# Altium schematic sheet [02] - HAB.SchDoc("[02] - HAB")
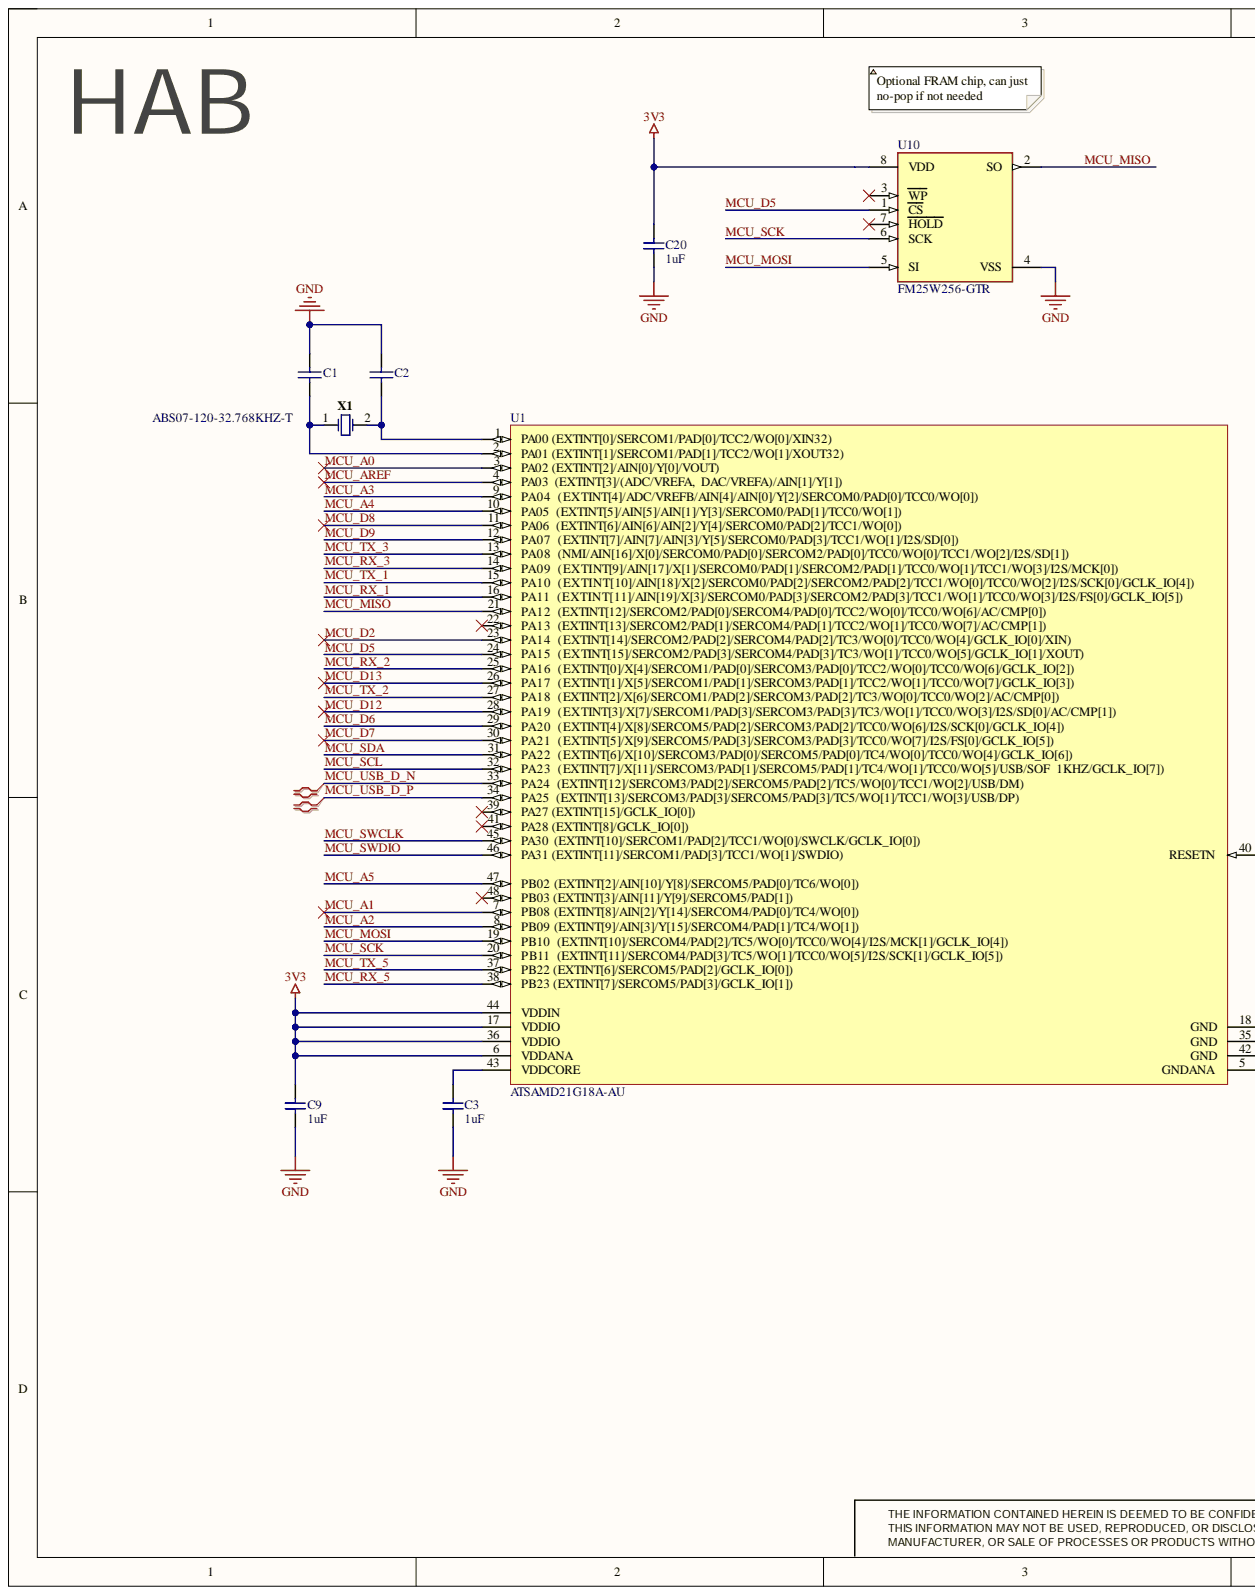
[diagram p0: [02] - HAB.SchDoc, left]
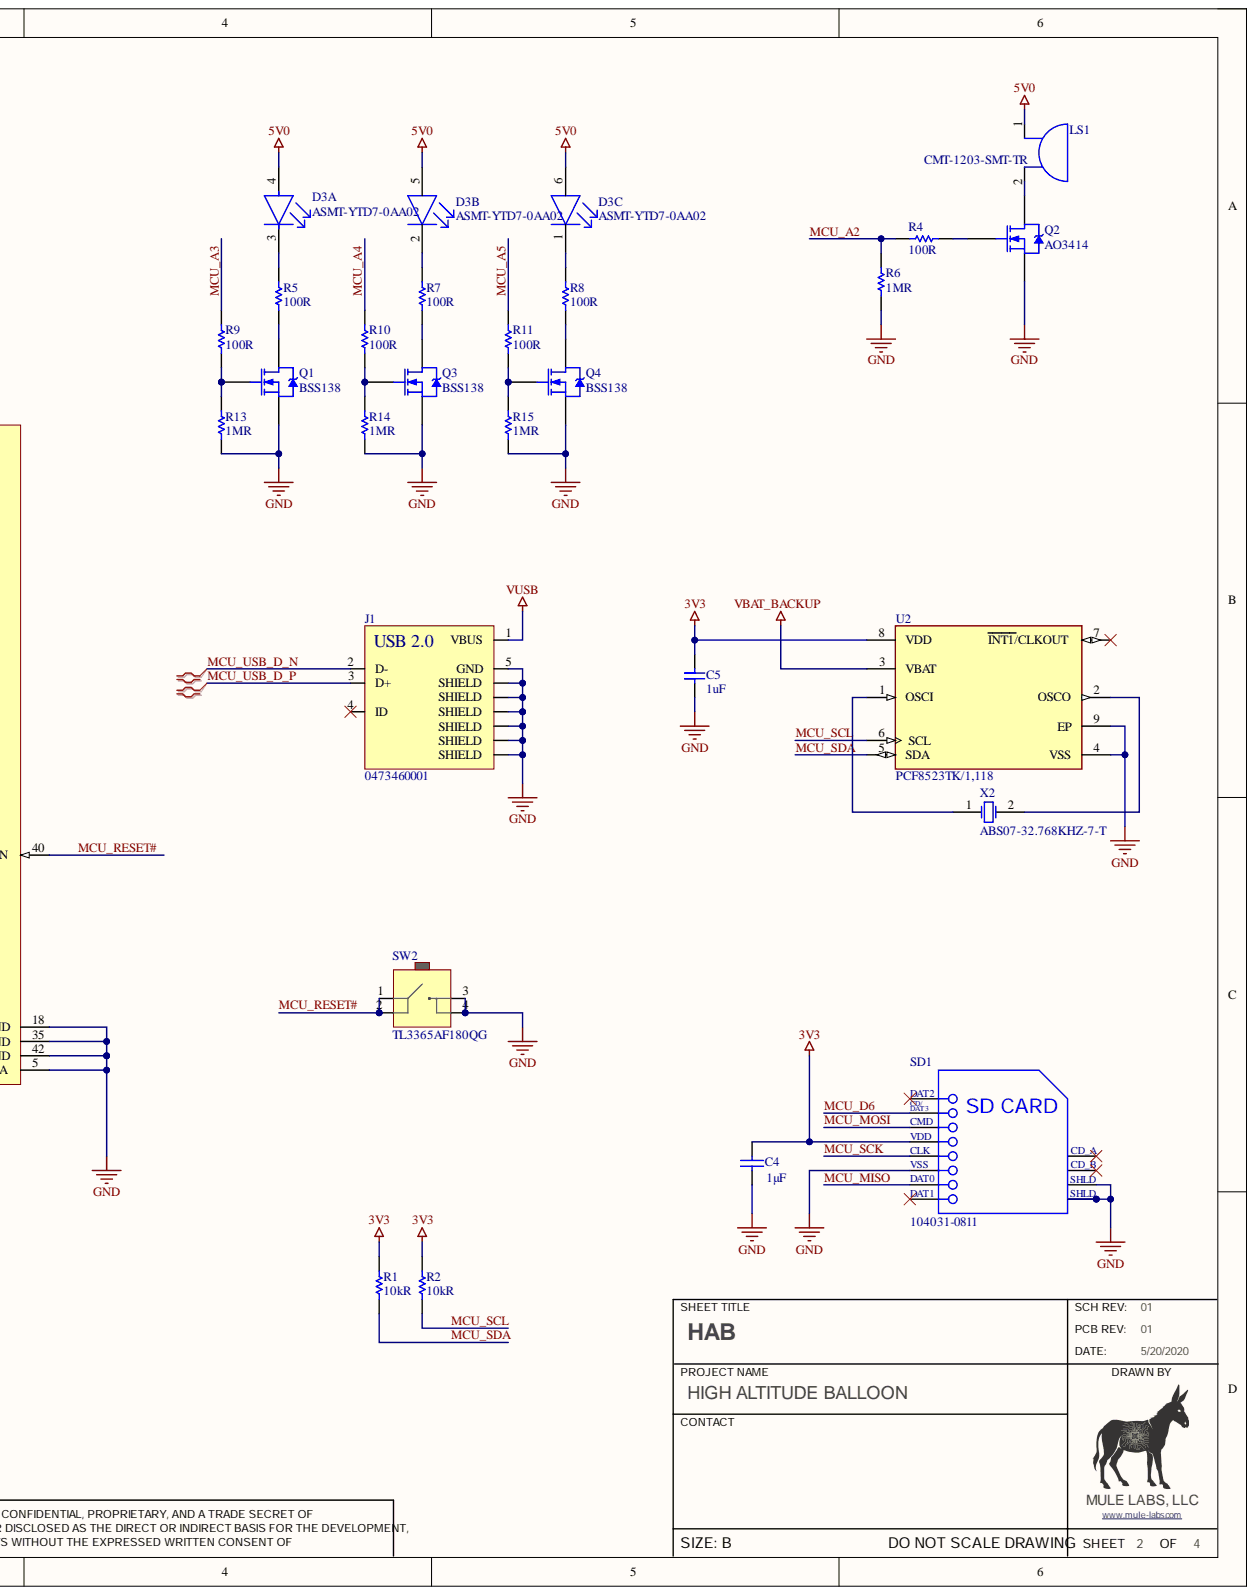
[diagram p1: [02] - HAB.SchDoc, right]

## Parts
C1  GRM21A5C2E220JW01D  [CAPC2013X100X30ML20]  (value not drawn)
C2  GRM21A5C2E220JW01D  [CAPC2013X100X30ML20]  (value not drawn)
C3  1uF  [INCH 0805 - METRIC 2012 - H140]
C4  Capacitance: 1µF  [CAPC2013X135X45ML10T25]  (hidden comment: GRM21BR71C105KA01L)
C5  1uF  [INCH 0805 - METRIC 2012 - H140]
C9  1uF  [INCH 0805 - METRIC 2012 - H140]
C20  1uF  [INCH 0805 - METRIC 2012 - H140]
D3  ASMT-YTD7-0AA02  [FP-PLCC-6-MFG]  (parts A,B,C)
J1  0473460001  Connector Type: USB  [MOLEX_047346-0001]
LS1  CMT-1203-SMT-TR  [CMT-1203-SMT-TR]
Q1  BSS138  [SOT95P240X120-3]
Q2  AO3414  [SOT95P240X120-3]
Q3  BSS138  [SOT95P240X120-3]
Q4  BSS138  [SOT95P240X120-3]
R1  10kR  [INCH 0805 - METRIC 2012 - H070]
R2  10kR  [INCH 0805 - METRIC 2012 - H070]
R4  100R  [INCH 0805 - METRIC 2012 - H070]
R5  100R  [INCH 0805 - METRIC 2012 - H070]
R6  1MR  [INCH 0805 - METRIC 2012 - H070]
R7  100R  [INCH 0805 - METRIC 2012 - H070]
R8  100R  [INCH 0805 - METRIC 2012 - H070]
R9  100R  [INCH 0805 - METRIC 2012 - H070]
R10  100R  [INCH 0805 - METRIC 2012 - H070]
R11  100R  [INCH 0805 - METRIC 2012 - H070]
R13  1MR  [INCH 0805 - METRIC 2012 - H070]
R14  1MR  [INCH 0805 - METRIC 2012 - H070]
R15  1MR  [INCH 0805 - METRIC 2012 - H070]
SD1  104031-0811  [MOLX-104031-0811_V]
SW2  TL3365AF180QG  [ESWITCH_TL3365AF180QG]
U1  ATSAMD21G18A-AU  [ATML-TQFP48_M]
U2  PCF8523TK/1,118  [FP-SOT909-1-MFG]
U10  FM25W256-GTR  [FP-51-85066-MFG]
X1  ABS07-120-32.768KHZ-T  [ABRA-ABS07_A_V]
X2  ABS07-32.768KHZ-7-T  [ABS07]

## Nets
3V3: C4.1 C5.2 C9.2 C20.2 R1.2 R2.2 SD1.4 U1.6(VDDANA) U1.17(VDDIO) U1.36(VDDIO) U1.44(VDDIN) U2.8(VDD) U10.8(VDD)
5V0: D3.4 D3.5 D3.6 LS1.1
GND: C1.2 C2.2 C3.1 C4.2 C5.1 C9.1 C20.1 J1.0(SHIELD) J1.5(GND) Q1.2 Q2.2 Q3.2 Q4.2 R6.1 R13.1 R14.1 R15.1 SD1.6 SD1.11 SD1.12 SW2.3 SW2.4 U1.5(GNDANA) U1.18(GND) U1.35(GND) U1.42(GND) U2.4(VSS) U2.9(EP) U10.4(VSS)
VBAT_BACKUP: U2.3(VBAT)
VUSB: J1.1(VBUS)
MCU_A0: U1.3(PA02_(EXTINT[2]/AIN[0]/Y[0]/VOUT))
MCU_A1: U1.7(PB08_(EXTINT[8]/AIN[2]/Y[14]/SERCOM4/PAD[0]/TC4/WO[0]))
MCU_A2: R4.1 R6.2 U1.8(PB09_(EXTINT[9]/AIN[3]/Y[15]/SERCOM4/PAD[1]/TC4/WO[1]))
MCU_A3: R9.2 U1.9(PA04)
MCU_A4: R10.2 U1.10(PA05)
MCU_A5: R11.2 U1.47(PB02_(EXTINT[2]/AIN[10]/Y[8]/SERCOM5/PAD[0]/TC6/WO[0]))
MCU_AREF: U1.4(PA03)
MCU_D2: U1.23(PA14)
MCU_D5: U1.24(PA15) U10.1(CS)
MCU_D6: SD1.2 U1.29(PA20)
MCU_D7: U1.30(PA21)
MCU_D8: U1.11(PA06)
MCU_D9: U1.12(PA07)
MCU_D12: U1.28(PA19)
MCU_D13: U1.26(PA17)
MCU_MISO: SD1.7 U1.21(PA12) U10.2(SO)
MCU_MOSI: SD1.3 U1.19(PB10) U10.5(SI)
MCU_RESET#: SW2.1 SW2.2 U1.40(RESETN)
MCU_RX_1: U1.16(PA11)
MCU_RX_2: U1.25(PA16)
MCU_RX_3: U1.14(PA09)
MCU_RX_5: U1.38(PB23_(EXTINT[7]/SERCOM5/PAD[3]/GCLK_IO[1]))
MCU_SCK: SD1.5 U1.20(PB11) U10.6(SCK)
MCU_SCL: R2.1 U1.32(PA23) U2.6(SCL)
MCU_SDA: R1.1 U1.31(PA22) U2.5(SDA)
MCU_SWCLK: U1.45(PA30)
MCU_SWDIO: U1.46(PA31_(EXTINT[11]/SERCOM1/PAD[3]/TCC1/WO[1]/SWDIO))
MCU_TX_1: U1.15(PA10)
MCU_TX_2: U1.27(PA18)
MCU_TX_3: U1.13(PA08)
MCU_TX_5: U1.37(PB22_(EXTINT[6]/SERCOM5/PAD[2]/GCLK_IO[0]))
MCU_USB_D_N: J1.2(D-) U1.33(PA24)
MCU_USB_D_P: J1.3(D+) U1.34(PA25)
NetC1_1: C1.1 U1.2(PA01_(EXTINT[1]/SERCOM1/PAD[1]/TCC2/WO[1]/XOUT32)) X1.1
NetC2_1: C2.1 U1.1(PA00_(EXTINT[0]/SERCOM1/PAD[0]/TCC2/WO[0]/XIN32)) X1.2
NetC3_2: C3.2 U1.43(VDDCORE)
NetD3_1: D3.1 R8.2
NetD3_2: D3.2 R7.2
NetD3_3: D3.3 R5.2
NetLS1_2: LS1.2 Q2.3
NetQ1_1: Q1.1 R9.1 R13.2
NetQ1_3: Q1.3 R5.1
NetQ2_1: Q2.1 R4.2
NetQ3_1: Q3.1 R10.1 R14.2
NetQ3_3: Q3.3 R7.1
NetQ4_1: Q4.1 R11.1 R15.2
NetQ4_3: Q4.3 R8.1
NetU2_1: U2.1(OSCI) X2.1
NetU2_2: U2.2(OSCO) X2.2

Not connected: J1 4(ID); SD1 1(SD_CARD) 8 9 10; U1 22(PA13) 39(PA27_(EXTINT[15]/GCLK_IO[0])) 41(PA28_(EXTINT[8]/GCLK_IO[0])) 48(PB03_(EXTINT[3]/AIN[11]/Y[9]/SERCOM5/PAD[1])); U2 7(INT1/CLKOUT); U10 3(WP) 7(HOLD)

## Library data of the parts
C1 C2 = CMP-2007-03977-1: Manufacturer: Murata; Component Kind: Standard; Library Name: Altium Content Vault; Part Number: GRM21A5C2E220JW01D
C3 C5 C9 C20 = GCM21BR71E105KA56L: CAP SMD 1uF 25V 10% 0805; Manufacturer: Murata; Dielectric: X7R; Capacitance: 1uF; Case Code (Imperial): 0805; Case Code (Metric): 2012; Case/Package: 0805; Category: Ceramic Capacitors; Component Kind: Standard; ComponentLink1Description: https://datasheet.ciiva.com/3778/359gcm21br71e105ka5623-3778560.pdf?src-supplier=Arrow; ComponentLink1URL: https://datasheet.ciiva.com/3778/359gcm21br71e105ka5623-3778560.pdf?src-supplier=Arrow; ComponentLink2Description: https://datasheet.ciiva.com/16624/product-16624576.pdf?src-supplier=Avnet+Europe; ComponentLink2URL: https://datasheet.ciiva.com/16624/product-16624576.pdf?src-supplier=Avnet+Europe; ComponentLink3Description: https://datasheet.ciiva.com/18183/getdatasheetpartid-153106-18183696.pdf?src-supplier=Verical; ComponentLink3URL: https://datasheet.ciiva.com/18183/getdatasheetpartid-153106-18183696.pdf?src-supplier=Verical; ComponentLink4Description: https://datasheet.ciiva.com/11789/0900766b80ef92d0-11789092.pdf?src-supplier=RSComponents; ComponentLink4URL: https://datasheet.ciiva.com/11789/0900766b80ef92d0-11789092.pdf?src-supplier=RSComponents; ComponentLink5Description: https://datasheet.ciiva.com/137/product-137199.pdf?src-supplier=Digi-Key; ComponentLink5URL: https://datasheet.ciiva.com/137/product-137199.pdf?src-supplier=Digi-Key; ComponentLink6Description: https://datasheet.ciiva.com/137/product-137200.pdf?src-supplier=Digi-Key; ComponentLink6URL: https://datasheet.ciiva.com/137/product-137200.pdf?src-supplier=Digi-Key; ComponentLink7Description: https://datasheet.ciiva.com/137/product-137201.pdf?src-supplier=Digi-Key; ComponentLink7URL: https://datasheet.ciiva.com/137/product-137201.pdf?src-supplier=Digi-Key; ComponentLink8Description: https://datasheet.ciiva.com/2989/product-2989245.pdf?src-supplier=Avnet; ComponentLink8URL: https://datasheet.ciiva.com/2989/product-2989245.pdf?src-supplier=Avnet; ComponentLink9Description: https://datasheet.ciiva.com/2989/product-2989246.pdf?src-supplier=Avnet; ComponentLink9URL: https://datasheet.ciiva.com/2989/product-2989246.pdf?src-supplier=Avnet; Depth: 1.25mm; Height: 1.25mm; Lead Free: Lead Free; Length: 2mm; Library Name: Capacitors.IntLib; Manufacturer Part Number: GCM21BR71E105KA56L; Max Operating Temperature: 125°C; Min Operating Temperature: -55°C; Mount: Surface Mount; Package Quantity: 3000; Packaging: Tape and Reel; Pricing 1: 1=0.33000, 10=0.22500, 100=0.12760, 500=0.09004, 1000=0.07878 (USD); Radiation Hardening: No; Ratings: AEC-Q200; REACH SVHC: No SVHC; RoHS: Yes; Stock 1: 1572955; Supplier 1: Digi-Key; Supplier Part Number 1: 490-4785-1-ND; Termination: SMD/SMT; Thickness: 0.055inch; Tolerance: 10%; Voltage: 25V; Voltage Rating: 25V; Voltage Rating (DC): 25V; Width: 0.049inch
C4 = CMP-2000-04946-1: Multilayer Ceramic Capacitor, 1 uF, +/- 10%, 16 V, -55 to 125 degC, 0805 (2012 Metric), RoHS, Tape and Reel; Manufacturer: Murata; Dielectric: X7R; Rated Voltage: 16V; Case Size/Dimension: 0805; Component Kind: Standard; Datasheet URL: https://datasheet.ciiva.com/34302/1858922-34302874.pdf; Datasheet Version: Mar-08; Library Name: Altium Content Vault; Manufacturer URL: http://www.murata.com/; Package Description: 2-Pin Surface Mount Device, Body 2 x 1.25 mm; Package Reference: 805; Tolerance (%): ±10%
D3 = CMP-18399-000005-1: LED RGB DIFFUSED 6PLCC SMD; Manufacturer: Broadcom; Colour: Red, Green, Blue; Forward Current: 20mA; Forward Voltage: 2.1/3.1/3.1V; Led Orientation: Top View; Luminous Intensity: 650/1900/384mcd; Max Junction Temp: +110°C; Peak Emmision Wavelength: 630/522/465nm; Power Dissipation: 125/90/90mW; Reverse Voltage: 4V; Viewing Angle: 120°; Wavelength: 623/529/469nm; Automotive: No; Category: Diode; Component Kind: Standard; ComponentLink2Description: Datasheet; ComponentLink2URL: https://docs.broadcom.com/doc/AV02-3873EN; Device Class L1: Optoelectronics; Device Class L2: LEDs; Device Class L3: unset; Digikey Description: LED RGB DIFFUSED 6PLCC SMD; Height: 2mm; Lead Free: Yes; Library Name: Altium Content Vault; Manufacturer Part Number: ASMT-YTD7-0AA02; Mouser Description: Standard LEDs - SMD PLCC6,T/MT SILICONE RGB; Octopart: https://octopart.com/asmt-ytd7-0aa02-broadcom-71127359; Package: PLCC-6; RoHS: TRUE; Temperature: -40°C to +100°C; Temperature Range High: +100°C; Temperature Range Low: -40°C
J1 = 0473460001: Conn Micro USB Type B RCP 5 POS 0.65mm Solder RA SMD 5 Terminal 1 Port Embossed T/R; Manufacturer: Molex; Gender: Receptacle; Max Voltage Rating (AC): 30V; Number of Contacts: 5; Number of Ports: 1; Category: Connector; Component Kind: Standard; ComponentLink1Description: https://datasheet.ciiva.com/6731/473460001-sd-6731041.pdf; ComponentLink1URL: https://datasheet.ciiva.com/6731/473460001-sd-6731041.pdf; ComponentLink2Description: https://datasheet.ciiva.com/16545/0473460001-io-connectors-16545778.pdf; ComponentLink2URL: https://datasheet.ciiva.com/16545/0473460001-io-connectors-16545778.pdf; ComponentLink3Description: https://datasheet.ciiva.com/3094/0473460001-io-connectors-3094381.pdf; ComponentLink3URL: https://datasheet.ciiva.com/3094/0473460001-io-connectors-3094381.pdf; Contact Material: Copper Alloy; Contact Plating: Nickel,Gold; Depth: 5.43mm; Housing Material: Polymer; Length: 7.8mm; Library Name: Connectors.IntLib; Manufacturer Part Number: 0473460001; Max Operating Temperature: 85°C; Min Operating Temperature: -30°C; Mount: Surface Mount,Horizontal; Number of Terminals: 5; Orientation: Right Angle; Package Quantity: 1; Packaging: Tape and Reel; Pitch: 0.65mm; Pricing 1: 1=1, 10=0.877, 25=0.8252, 50=0.7906, 100=0.7563, 250=0.68752, 500=0.61876, 1000=0.55 (USD); Radiation Hardening: No; Stock 1: 27309; Supplier 1: Digi-Key; Supplier Part Number 1: WM17141CT-ND; Termination: Solder
LS1 = CMT-1203-SMT-TR: AUDIO PIEZO TRANSDUCER 25V SMD; Manufacturer: CUI; Frequency: 4kHz; Operating Supply Current: 5mA; Voltage Rating: 5V; Case/Package: SMD/SMT; Category: Audio Products; Component Kind: Standard; ComponentLink1Description: http://www.mouser.com/ds/2/670/cmt-1203-smt-515906.pdf?src-supplier=Mouser; ComponentLink1URL: http://www.mouser.com/ds/2/670/cmt-1203-smt-515906.pdf?src-supplier=Mouser; ComponentLink2Description: https://www.cui.com/product/resource/digikeypdf/cmt-1203-smt.pdf?src-supplier=Digi-Key; ComponentLink2URL: https://www.cui.com/product/resource/digikeypdf/cmt-1203-smt.pdf?src-supplier=Digi-Key; Height: 3.3mm; Lead Free: Lead Free; Length: 12mm; Manufacturer Part Number: CMT-1203-SMT-TR; Max Operating Temperature: 120°C; Min Operating Temperature: -40°C; Mount: Surface Mount; Package Quantity: 1000; Packaging: Tape and Reel; Pricing 1: 1=1.99000, 10=1.64900, 25=1.52400, 50=1.43320, 100=1.34560, 500=1.15502 (USD); RoHS: Yes; Stock 1: 22304; Supplier 1: Digi-Key; Supplier Part Number 1: 102-2197-1-ND; Termination: Solder; Width: 12mm; Library Name: Buzzers.IntLib
Q1 Q3 Q4 = BSS138: MOSFET N-CH 50V 220MA SOT-23; Manufacturer: ON Semiconductor / Fairchild; Drain to Source Resistance: 3.5R; Element Configuration: Single; Fall Time: 9ns; Gate to Source Voltage (Vgs): 20V; Input Capacitance: 27pF; Max Power Dissipation: 360mW; Nominal Vgs: 1.3V; Number of Elements: 1; On-State Resistance: 6R; Turn-Off Delay Time: 20ns; Turn-On Delay Time: 2.5ns; Case/Package: SOT-23; Category: Transistor; Component Kind: Standard; ComponentLink1Description: https://datasheet.ciiva.com/931/bss138-931776.pdf; ComponentLink1URL: https://datasheet.ciiva.com/931/bss138-931776.pdf; ComponentLink2Description: https://datasheet.ciiva.com/1190/193736-1190130.pdf; ComponentLink2URL: https://datasheet.ciiva.com/1190/193736-1190130.pdf; ComponentLink3Description: https://datasheet.ciiva.com/1190/193736-1190131.pdf; ComponentLink3URL: https://datasheet.ciiva.com/1190/193736-1190131.pdf; ComponentLink4Description: https://datasheet.ciiva.com/1254/193736-1254983.pdf; ComponentLink4URL: https://datasheet.ciiva.com/1254/193736-1254983.pdf; ComponentLink5Description: https://datasheet.ciiva.com/3304/bss138-3304423.pdf; ComponentLink5URL: https://datasheet.ciiva.com/3304/bss138-3304423.pdf; ComponentLink6Description: https://datasheet.ciiva.com/3304/bss138-3304424.pdf; ComponentLink6URL: https://datasheet.ciiva.com/3304/bss138-3304424.pdf; ComponentLink7Description: https://datasheet.ciiva.com/22655/193736-22655240.pdf; ComponentLink7URL: https://datasheet.ciiva.com/22655/193736-22655240.pdf; ComponentLink8Description: https://datasheet.ciiva.com/16834/bss138-16834843.pdf; ComponentLink8URL: https://datasheet.ciiva.com/16834/bss138-16834843.pdf; ComponentLink9Description: https://datasheet.ciiva.com/27050/784896-27050043.pdf; ComponentLink9URL: https://datasheet.ciiva.com/27050/784896-27050043.pdf; ComponentLink10Description: https://datasheet.ciiva.com/26229/bss138-26229007.pdf; ComponentLink10URL: https://datasheet.ciiva.com/26229/bss138-26229007.pdf; Contact Plating: Tin,Matte; Continuous Drain Current (ID): 220mA; Current Rating: 220mA; Drain to Source Breakdown Voltage: 50V; Drain to Source Voltage (Vdss): 50V; Dual Supply Voltage: 50V; Height: 0.93mm; Lead Free: Lead Free; Length: 2.92mm; Library Name: Transistors - FET.IntLib; Manufacturer Part Number: BSS138; Max Operating Temperature: 150°C; Min Operating Temperature: -55°C; Mount: Surface Mount; Number of Pins: 3; Package Quantity: 1; Packaging: Tape and Reel; Power Dissipation: 360mW; Pricing 1: 1=0.32, 10=0.292, 100=0.1633, 500=0.0875, 1000=0.0595 (USD); Radiation Hardening: No; Rds On Max: 3.5R; REACH SVHC: No SVHC; Rise Time: 9ns; Stock 1: 1717197; Supplier 1: Digi-Key; Supplier Part Number 1: BSS138CT-ND; Termination: SMD/SMT; Threshold Voltage: 1.3V; Voltage Rating (DC): 50V; Weight: 0.03g; Width: 1.3mm
Q2 = AO3414: MOSFET N-CH 20V 4.2A SOT23; Manufacturer: Alpha & Omega Semiconductor; Continuous Drain Current (ID): 3A; Gate to Source Voltage (Vgs): 8V; Input Capacitance: 436pF; Max Power Dissipation: 1.4W; Number of Elements: 1; Rds On Max: 50mR; Case/Package: SOT-23; Component Kind: Standard; ComponentLink1Description: http://aosmd.com/res/packaging_information/SOT-23-3L.pdf; ComponentLink1URL: http://aosmd.com/res/packaging_information/SOT-23-3L.pdf; ComponentLink2Description: https://www.verical.com/server-webapp/html/getDatasheet?partID=64433; ComponentLink2URL: https://www.verical.com/server-webapp/html/getDatasheet?partID=64433; Drain to Source Voltage (Vdss): 20V; Lead Free: Lead Free; Library Name: Transistors - FET.IntLib; Manufacturer Part Number: AO3414; Max Operating Temperature: 150°C; Min Operating Temperature: -55°C; Mount: Surface Mount; Number of Pins: 3; Packaging: Tape and Reel; Power Dissipation: 1.4W; Pricing 1: 1=0.5, 10=0.357, 100=0.2102, 500=0.11896, 1000=0.09121 (USD); Radiation Hardening: No; Stock 1: 451497; Supplier 1: Digi-Key; Supplier Part Number 1: 785-1009-1-ND
R1 R2 = ERJ-P06F1002V: RES SMD 10kOHM 1% 1/2W 0805; Manufacturer: Panasonic; Power Rating: 500mW; Temperature Coefficient: 100ppm/°C; Voltage Rating: 150V; Case Code (Imperial): 0805; Case Code (Metric): 2012; Case/Package: 0805; Category: Chip SMD Resistors; Component Kind: Standard; ComponentLink1Description: https://datasheet.ciiva.com/11811/0900766b812cb812-11811124.pdf?src-supplier=RSComponents; ComponentLink1URL: https://datasheet.ciiva.com/11811/0900766b812cb812-11811124.pdf?src-supplier=RSComponents; ComponentLink2Description: https://datasheet.ciiva.com/24069/aoa0000ce4-64075-24069191.pdf?src-supplier=Mouser; ComponentLink2URL: https://datasheet.ciiva.com/24069/aoa0000ce4-64075-24069191.pdf?src-supplier=Mouser; ComponentLink3Description: https://datasheet.ciiva.com/48426/erjp-series-eng-tds-48426779.pdf?src-supplier=Distrelec; ComponentLink3URL: https://datasheet.ciiva.com/48426/erjp-series-eng-tds-48426779.pdf?src-supplier=Distrelec; Composition: Thick Film; Contact Plating: Tin; Depth: 1.25mm; Height: 0.6mm; Lead Free: Lead Free; Length: 2mm; Library Name: Resistors.IntLib; Manufacturer Part Number: ERJ-P06F1002V; Max Operating Temperature: 155°C; Military Standard: Not; Min Operating Temperature: -55°C; Mount: Surface Mount; Package Quantity: 5000; Packaging: Tape and Reel; Pricing 1: 1=0.17000, 10=0.14800, 100=0.05770, 1000=0.02416, 2500=0.02214 (USD); Radiation Hardening: No; REACH SVHC: No SVHC; Resistance: 10kR; RoHS: Yes; Stock 1: 119213; Supplier 1: Digi-Key; Supplier Part Number 1: P16059CT-ND; Termination: SMD/SMT; Tolerance: 1%; Voltage Rating (DC): 150V; Width: 1.2mm
R4 R5 R7-R11 = ERJ-P06J101V: RES SMD 100 OHM 5% 1/2W 0805; Manufacturer: Panasonic; Max Power Dissipation: 500mW; Temperature Coefficient: 200ppm/°C; Voltage Rating: 400V; Voltage Rating (DC): 150V; Case Code (Imperial): 0805; Case Code (Metric): 2012; Case/Package: 0805; Category: Chip SMD Resistors; Component Kind: Standard; ComponentLink1Description: https://datasheet.ciiva.com/11810/0900766b812cb812-11810156.pdf?src-supplier=RSComponents; ComponentLink1URL: https://datasheet.ciiva.com/11810/0900766b812cb812-11810156.pdf?src-supplier=RSComponents; ComponentLink2Description: https://datasheet.ciiva.com/16788/70068906-16788678.pdf?src-supplier=Allied+Electronics; ComponentLink2URL: https://datasheet.ciiva.com/16788/70068906-16788678.pdf?src-supplier=Allied+Electronics; ComponentLink3Description: https://datasheet.ciiva.com/7831/aoa0000ce4-7831000.pdf?src-supplier=Element14; ComponentLink3URL: https://datasheet.ciiva.com/7831/aoa0000ce4-7831000.pdf?src-supplier=Element14; ComponentLink4Description: https://datasheet.ciiva.com/16767/70068906-16767564.pdf?src-supplier=Allied+Electronics; ComponentLink4URL: https://datasheet.ciiva.com/16767/70068906-16767564.pdf?src-supplier=Allied+Electronics; ComponentLink5Description: https://datasheet.ciiva.com/9186/aoa0000ce4-9186844.pdf?src-supplier=Avnet; ComponentLink5URL: https://datasheet.ciiva.com/9186/aoa0000ce4-9186844.pdf?src-supplier=Avnet; ComponentLink6Description: https://datasheet.ciiva.com/24163/aoa0000ce4-64075-24163318.pdf?src-supplier=Mouser; ComponentLink6URL: https://datasheet.ciiva.com/24163/aoa0000ce4-64075-24163318.pdf?src-supplier=Mouser; ComponentLink7Description: https://datasheet.ciiva.com/17208/aoa0000ce4-17208010.pdf?src-supplier=Avnet+Europe; ComponentLink7URL: https://datasheet.ciiva.com/17208/aoa0000ce4-17208010.pdf?src-supplier=Avnet+Europe; Composition: Thick Film; Contact Plating: Tin; Depth: 1.25mm; Features: Automotive AEC-Q200; Height: 0.6mm; Lead Free: Lead Free; Length: 2mm; Library Name: Resistors.IntLib; Manufacturer Part Number: ERJ-P06J101V; Max Operating Temperature: 155°C; Military Standard: Not; Min Operating Temperature: -55°C; Mount: Surface Mount; Number of Terminations: 2; Package Quantity: 1; Packaging: Tape and Reel; Power Rating: 500mW; Pricing 1: 1=0.11000, 10=0.10300, 100=0.03960, 1000=0.01742, 2500=0.01505 (USD); REACH SVHC: Unknown; Resistance: 100R; RoHS: Yes; Stock 1: 141947; Supplier 1: Digi-Key; Supplier Part Number 1: P100ADCT-ND; Termination: SMD/SMT; Tolerance: 5%; Weight: 0.000194oz; Width: 1.2mm
R6 R13-R15 = ERJ-P06J105V: Res Thick Film 0805 1M Ohm 5% 1/4W 200ppm/C Molded SMD SMD Punched Carrier T/R; Manufacturer: Panasonic; Max Power Dissipation: 500mW; Temperature Coefficient: 200ppm/°C; Voltage Rating: 400V; Voltage Rating (DC): 150V; Case Code (Imperial): 0805; Case Code (Metric): 2012; Case/Package: 0805; Category: Chip SMD Resistors; Component Kind: Standard; ComponentLink1Description: https://datasheet.ciiva.com/11815/0900766b812cb812-11815881.pdf?src-supplier=RSComponents; ComponentLink1URL: https://datasheet.ciiva.com/11815/0900766b812cb812-11815881.pdf?src-supplier=RSComponents; ComponentLink2Description: https://datasheet.ciiva.com/16781/70068906-16781958.pdf?src-supplier=Allied+Electronics; ComponentLink2URL: https://datasheet.ciiva.com/16781/70068906-16781958.pdf?src-supplier=Allied+Electronics; ComponentLink3Description: https://datasheet.ciiva.com/16782/70068906-16782920.pdf?src-supplier=Allied+Electronics; ComponentLink3URL: https://datasheet.ciiva.com/16782/70068906-16782920.pdf?src-supplier=Allied+Electronics; ComponentLink4Description: https://datasheet.ciiva.com/16785/70068906-16785434.pdf?src-supplier=Allied+Electronics; ComponentLink4URL: https://datasheet.ciiva.com/16785/70068906-16785434.pdf?src-supplier=Allied+Electronics; ComponentLink5Description: https://datasheet.ciiva.com/7909/1913273-7909563.pdf?src-supplier=Element14; ComponentLink5URL: https://datasheet.ciiva.com/7909/1913273-7909563.pdf?src-supplier=Element14; ComponentLink6Description: https://datasheet.ciiva.com/24044/aoa0000ce4-64075-24044221.pdf?src-supplier=Mouser; ComponentLink6URL: https://datasheet.ciiva.com/24044/aoa0000ce4-64075-24044221.pdf?src-supplier=Mouser; ComponentLink7Description: https://datasheet.ciiva.com/17215/aoa0000ce4-17215262.pdf?src-supplier=Avnet+Europe; ComponentLink7URL: https://datasheet.ciiva.com/17215/aoa0000ce4-17215262.pdf?src-supplier=Avnet+Europe; Composition: Thick Film; Contact Plating: Tin; Depth: 1.25mm; Features: Automotive AEC-Q200; Height: 0.6mm; Lead Free: Lead Free; Length: 2mm; Library Name: Resistors.IntLib; Manufacturer Part Number: ERJ-P06J105V; Max Operating Temperature: 155°C; Military Standard: Not; Min Operating Temperature: -55°C; Mount: Surface Mount; Number of Terminations: 2; Package Quantity: 1; Packaging: Tape and Reel; Power Rating: 500mW; Pricing 1: 1=0.11000, 10=0.10300, 100=0.03960, 1000=0.01742, 2500=0.01505 (USD); Radiation Hardening: No; REACH SVHC: Unknown; Resistance: 1MR; RoHS: Yes; Stock 1: 44455; Supplier 1: Digi-Key; Supplier Part Number 1: P1.0MADCT-ND; Termination: SMD/SMT; Tolerance: 5%; Width: 0.049inch
SD1 = CMP-2000-05825-1: Micro SD Card, RA, -25 to 85 degC, 8-Pin SMD, RoHS, Tape and Reel; Brand: Molex; Current Rating: 500mA; Insertion - Extraction Style: Push-Pull; Mating Cycles: 10000; Number of Contacts: 8; Pitch: 1.1mm; Voltage Rating: 10VAC/DC; Card Type: microSD; Component Kind: Standard; Contact Material: PhosphorBronze; Contact Plating: Gold; Datasheet URL: http://www.molex.com/pdm_docs/sd/1040310811_sd.pdf; Datasheet Version: Rev. E, 04/2006; Height: 1.42mm; Housing Material: LiquidCrystalPolymer(LCP); Length: 11.4mm; Library Name: Altium Content Vault; Manufacturer: Molex; Manufacturer URL: http://www.molex.com/; Mounting Technology: SurfaceMount; Mounting Type: Standard; Number of Rows: 1Row; Orientation: RightAngle; Package Description: 8-Pin Surface Mount Device, Body 11.95 x 11.4 mm, Pitch 1.1 mm; Package Reference: 104031-0811; Packaging: Reel; Product: CardConnectors; Product Category: MemoryCardConnectors; RoHS: True; Series: 104031; Termination Style: SMD
SW2 = TL3365AF180QG: SWITCH TACTILE SPST-NO 0.05A 12V; Manufacturer: E-Switch; Illumination Type: Non-Illuminated; Mechanical Life: 100000Cycles; Operating Force: 180gf; Switch Function: Off-Mom; Switch Travel: 0.2mm; Throw Configuration: SPST; Component Kind: Standard; Lead Free: Lead Free; Library Name: Switches.IntLib; Manufacturer Part Number: TL3365AF180QG; Max Operating Temperature: 70°C; Min Operating Temperature: -20°C; Mount: Surface Mount; Packaging: Tape and Reel; Pricing 1: 1=0.34, 10=0.333, 25=0.3208, 50=0.3088, 100=0.297, 250=0.27324, 500=0.2376, 1000=0.20196 (USD); Stock 1: 55347; Supplier 1: Digi-Key; Supplier Part Number 1: EG5384CT-ND; Termination: Gull Wing
U1 = CMP-2100-03585-1: SMART ARM-Based Microcontroller, 1.62 to 3.63 V, 256 KB Flash, 32 KB SRAM, -40 to 85 degC, 48-Pin TQFP, RoHS, Tray; Manufacturer: Atmel; Frequency: 48MHz; Max Supply Voltage: 3.63V; Min Supply Voltage: 1.62V; Number of Timers/Counters: 6; Case/Package: TQFP; Component Kind: Standard; Contact Plating: Tin; Datasheet URL: http://www.atmel.com/images/atmel-42181-sam-d21_datasheet.pdf; Datasheet Version: Rev. N, 10/2016; Lead Free: LeadFree; Library Name: Altium Content Vault; Manufacturer URL: http://www.atmel.com/; Max Frequency: 48MHz; Max Operating Temperature: 85degC; Min Operating Temperature: -40degC; Mount: SurfaceMount; Number of Pins: 48; Package Description: 48-Pin Thin Profile Plastic Quad Flat Package, Body 7 x 7 mm, Pitch 0.5 mm; Package Reference: TQFP48; Packaging: Tray; REACH SVHC: NoSVHC; RoHSCompliant: true
U2 = CMP-10083-000004-1: IC RTC CLK/CALENDAR I2C 8-HVSON; Manufacturer: NXP Semiconductors; Max Supply Voltage: 5.5V; Min Supply Voltage: 1V; Nominal Supply Current: 0.15-1.2uA; Number Of Outputs: 1; Standoff Height: 0mm; Ambient Temperature Range High: +85°C; Ambient Temperature Range Low: -40°C; Automotive: No; Category: IC; Component Kind: Standard; ComponentLink2Description: Datasheet; ComponentLink2URL: https://www.nxp.com/docs/en/data-sheet/PCF8523.pdf; Device Class L1: Integrated Circuits (ICs); Device Class L2: Clock and Timing; Device Class L3: Real Time Clocks; Digikey Description: IC RTC CLK/CALENDAR I2C 8-HVSON; Footprint Url: https://www.nxp.com/docs/en/package-information/SOT909-1.pdf; Height: 1mm; Interface: I2C; Ipc Land Pattern Name: DFN400X400X100-8; Lead Free: Yes; Library Name: Altium Content Vault; Manufacturer Part Number: PCF8523TK/1,118; Mouser Description: Real Time Clock REAL-TIME CLOCK (RTC) AND CALENDAR; Octopart: https://octopart.com/pcf8523tk%2F1%2C118-nxp+semiconductors-19647992; Package: SOT909-1; RoHS: TRUE; Temperature: -40°C to +85°C; Temperature Range High: +85°C; Temperature Range Low: -40°C
U10 = CMP-12945-000012-1: IC FRAM 256K SPI 20MHZ 8SOIC; Manufacturer: Cypress Semiconductor; Max Supply Voltage: 5.5V; Memory Size: 256Kbits; Memory Type: OTHER; Min Supply Voltage: 2.7V; Nominal Supply Current: 0.25-2mA; Standoff Height: 0.1mm; Ambient Temperature Range High: +85°C; Ambient Temperature Range Low: -40°C; Automotive: No; Category: IC; Component Kind: Standard; ComponentLink2Description: Datasheet; ComponentLink2URL: https://www.cypress.com/file/41696/download; Device Class L1: Integrated Circuits (ICs); Device Class L2: Memory; Device Class L3: unset; Digikey Description: IC FRAM 256K SPI 20MHZ 8SOIC; Footprint Url: https://www.cypress.com/file/42881/download; Frequency: 20MHz; Height: 1.727mm; Interface: SPI; Ipc Land Pattern Name: SOIC127P602X164-8; Lead Free: Yes; Library Name: Altium Content Vault; Manufacturer Part Number: FM25W256-GTR; Mouser Description: F-RAM SPI FRAM 256k 3-5V; Octopart: https://octopart.com/fm25w256-gtr-cypress+semiconductor-25384375; Package: 51-85066; RoHS: TRUE; Temperature: -40°C to +85°C; Temperature Range High: +85°C; Temperature Range Low: -40°C
X1 = CMP-2000-07587-1: Tuning Fork Crystal, 32.768 KHz, +/- 20 ppm, -40 to 85 degC, 2-Pin SMD, RoHS, Tape and Reel; Manufacturer: Abracon; Case\/Package: SMD/SMT; ESR (Equivalent Series Resistance): 55KOhm; Frequency Tolerance: 20ppm; Load Capacitance: 6pF; Series Resistance: 60KOhm; Component Kind: Standard; Datasheet URL: http://www.abracon.com/Resonators/ABS07-120-32.768kHz-T.pdf; Datasheet Version: 11/2014; Depth: 1.5mm; Frequency: 32.768KHz; Height: 0.035inch; Lead Free: LeadFree; Length: 3.2mm; Library Name: Altium Content Vault; Manufacturer URL: http://www.abracon.com/; Max Operating Temperature: 85degC; Min Operating Temperature: -40degC; Mount: SurfaceMount; Mounting Technology: SurfaceMount; Number of Pins: 2; Package Description: 2-Pin Surface Mount Device, Body 3.2 x 1.5 mm; Package Quantity: 3000; Package Reference: ABS07; Packaging: TapeandReel; Radiation Hardening: No; REACH SVHC: NoSVHC; RoHSCompliant: True; Termination: SMD/SMT; Tolerance: 20ppm; Width: 0.061inch
X2 = ABS07-32.768KHZ-7-T: In a Pack of 10, Abracon 32.768kHz Crystal Unit ±20ppm SMD 2-Pin 3.2 x 1.5 x 0.9mm; ESR (Equivalent Series Resistance): 70kR; Insulation Resistance: 500MR; Load Capacitance: 7pF; Case/Package: CSMD; Category: Crystals; Component Kind: Standard; ComponentLink1Description: https://datasheet.ciiva.com/3174/abs07-3174144.pdf?src-supplier=Avnet; ComponentLink1URL: https://datasheet.ciiva.com/3174/abs07-3174144.pdf?src-supplier=Avnet; ComponentLink2Description: https://datasheet.ciiva.com/855/abs07-855947.pdf?src-supplier=Digi-Key; ComponentLink2URL: https://datasheet.ciiva.com/855/abs07-855947.pdf?src-supplier=Digi-Key; ComponentLink3Description: https://datasheet.ciiva.com/16199/abs07-16199467.pdf?src-supplier=Future+Electronics; ComponentLink3URL: https://datasheet.ciiva.com/16199/abs07-16199467.pdf?src-supplier=Future+Electronics; ComponentLink4Description: https://datasheet.ciiva.com/8344/abs07-8344589.pdf?src-supplier=Avnet; ComponentLink4URL: https://datasheet.ciiva.com/8344/abs07-8344589.pdf?src-supplier=Avnet; ComponentLink5Description: https://datasheet.ciiva.com/855/abs07-855945.pdf?src-supplier=Digi-Key; ComponentLink5URL: https://datasheet.ciiva.com/855/abs07-855945.pdf?src-supplier=Digi-Key; ComponentLink6Description: https://datasheet.ciiva.com/855/abs07-855946.pdf?src-supplier=Digi-Key; ComponentLink6URL: https://datasheet.ciiva.com/855/abs07-855946.pdf?src-supplier=Digi-Key; Depth: 1.5mm; Frequency: 32.768kHz; Frequency Stability: 20ppm; Frequency Tolerance: 20ppm; Height: 0.035inch; Lead Free: Lead Free; Length: 3.2mm; Library Name: Crystals Oscillators Resonators.IntLib; Manufacturer: Abracon; Manufacturer Part Number: ABS07-32.768KHZ-7-T; Max Operating Temperature: 85°C; Min Operating Temperature: -40°C; Mount: Surface Mount; Number of Pins: 2; Package Quantity: 3000; Packaging: Tape and Reel; Pricing 1: 1=1.09000, 10=0.96100, 50=0.89880, 100=0.79420, 500=0.75240, 1000=0.62700 (USD); Radiation Hardening: No; REACH SVHC: No SVHC; RoHS: Yes; Series Resistance: 70kR; Stock 1: 166337; Supplier 1: Digi-Key; Supplier Part Number 1: 535-9543-1-ND; Termination: SMD/SMT; Tolerance: 20ppm; Width: 1.5mm
